# Altium schematic sheet Board03Schematic.SchDoc
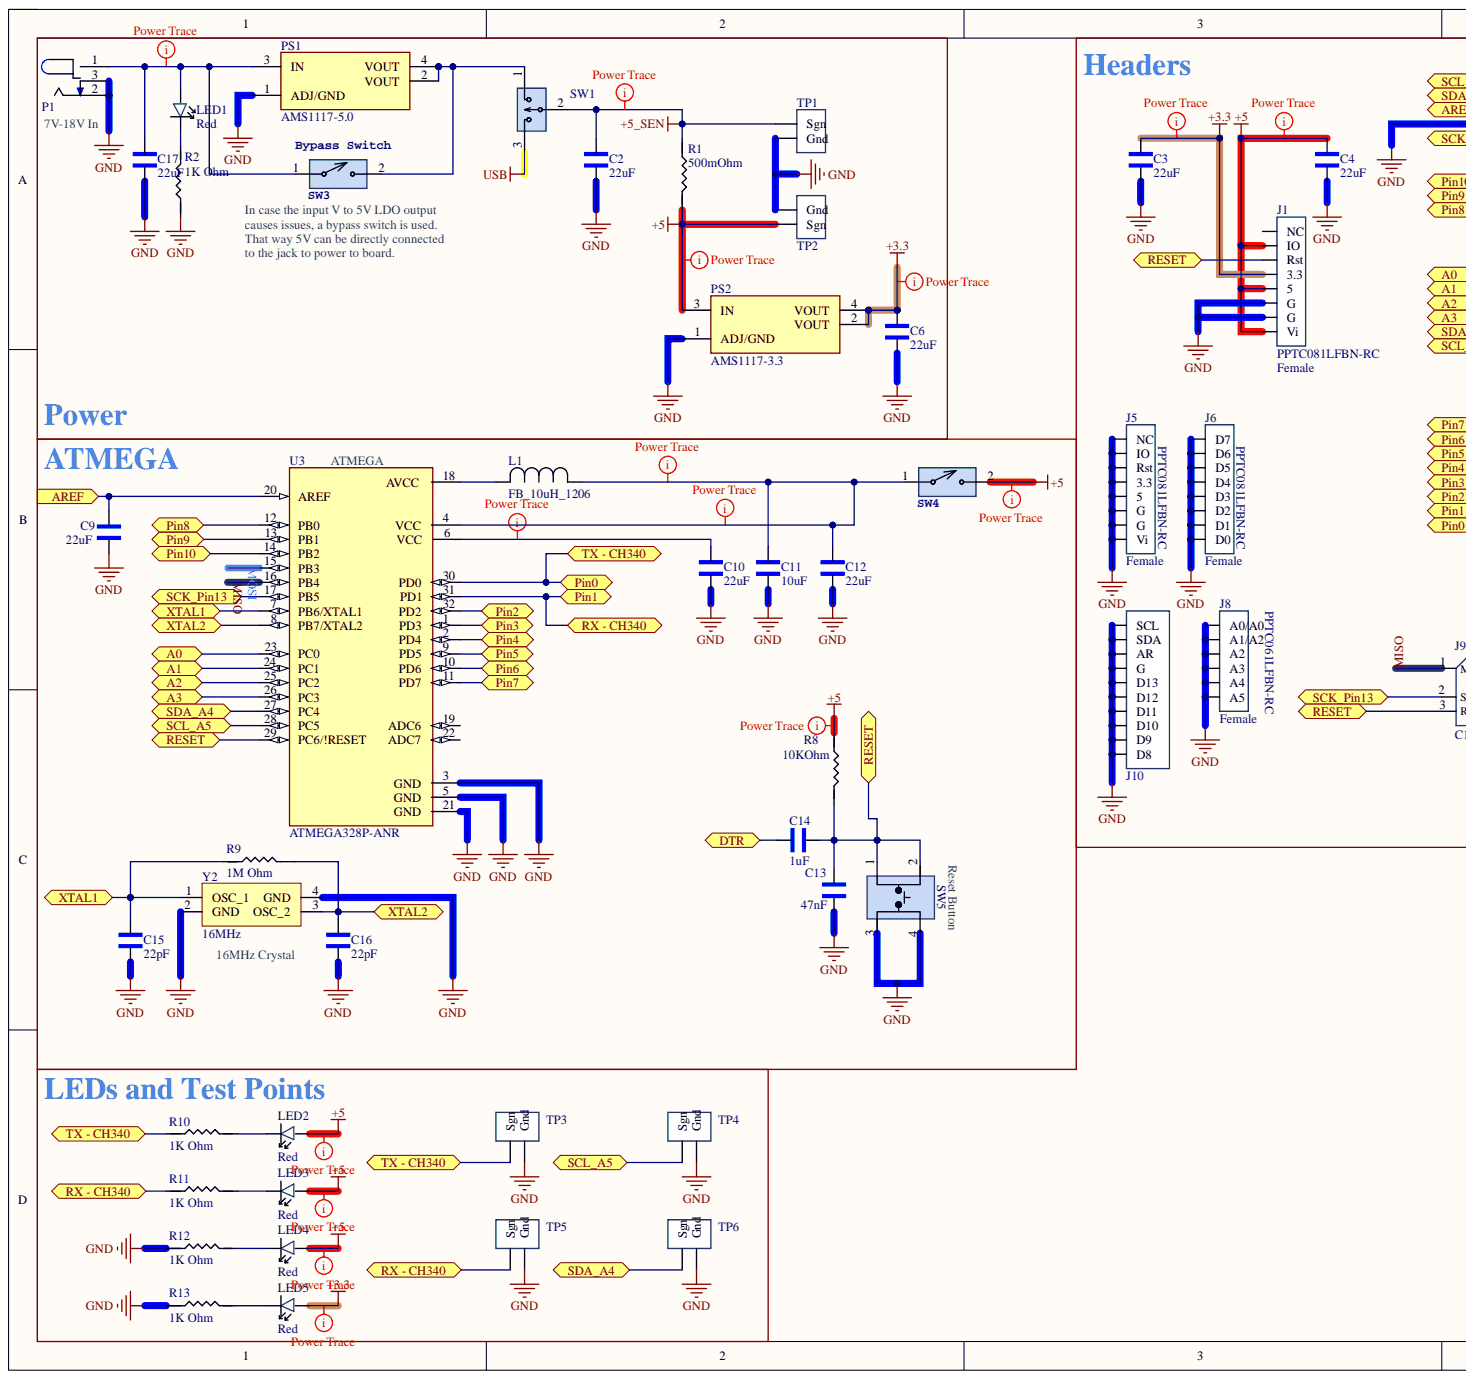
[diagram p0: Board03Schematic.SchDoc, left]
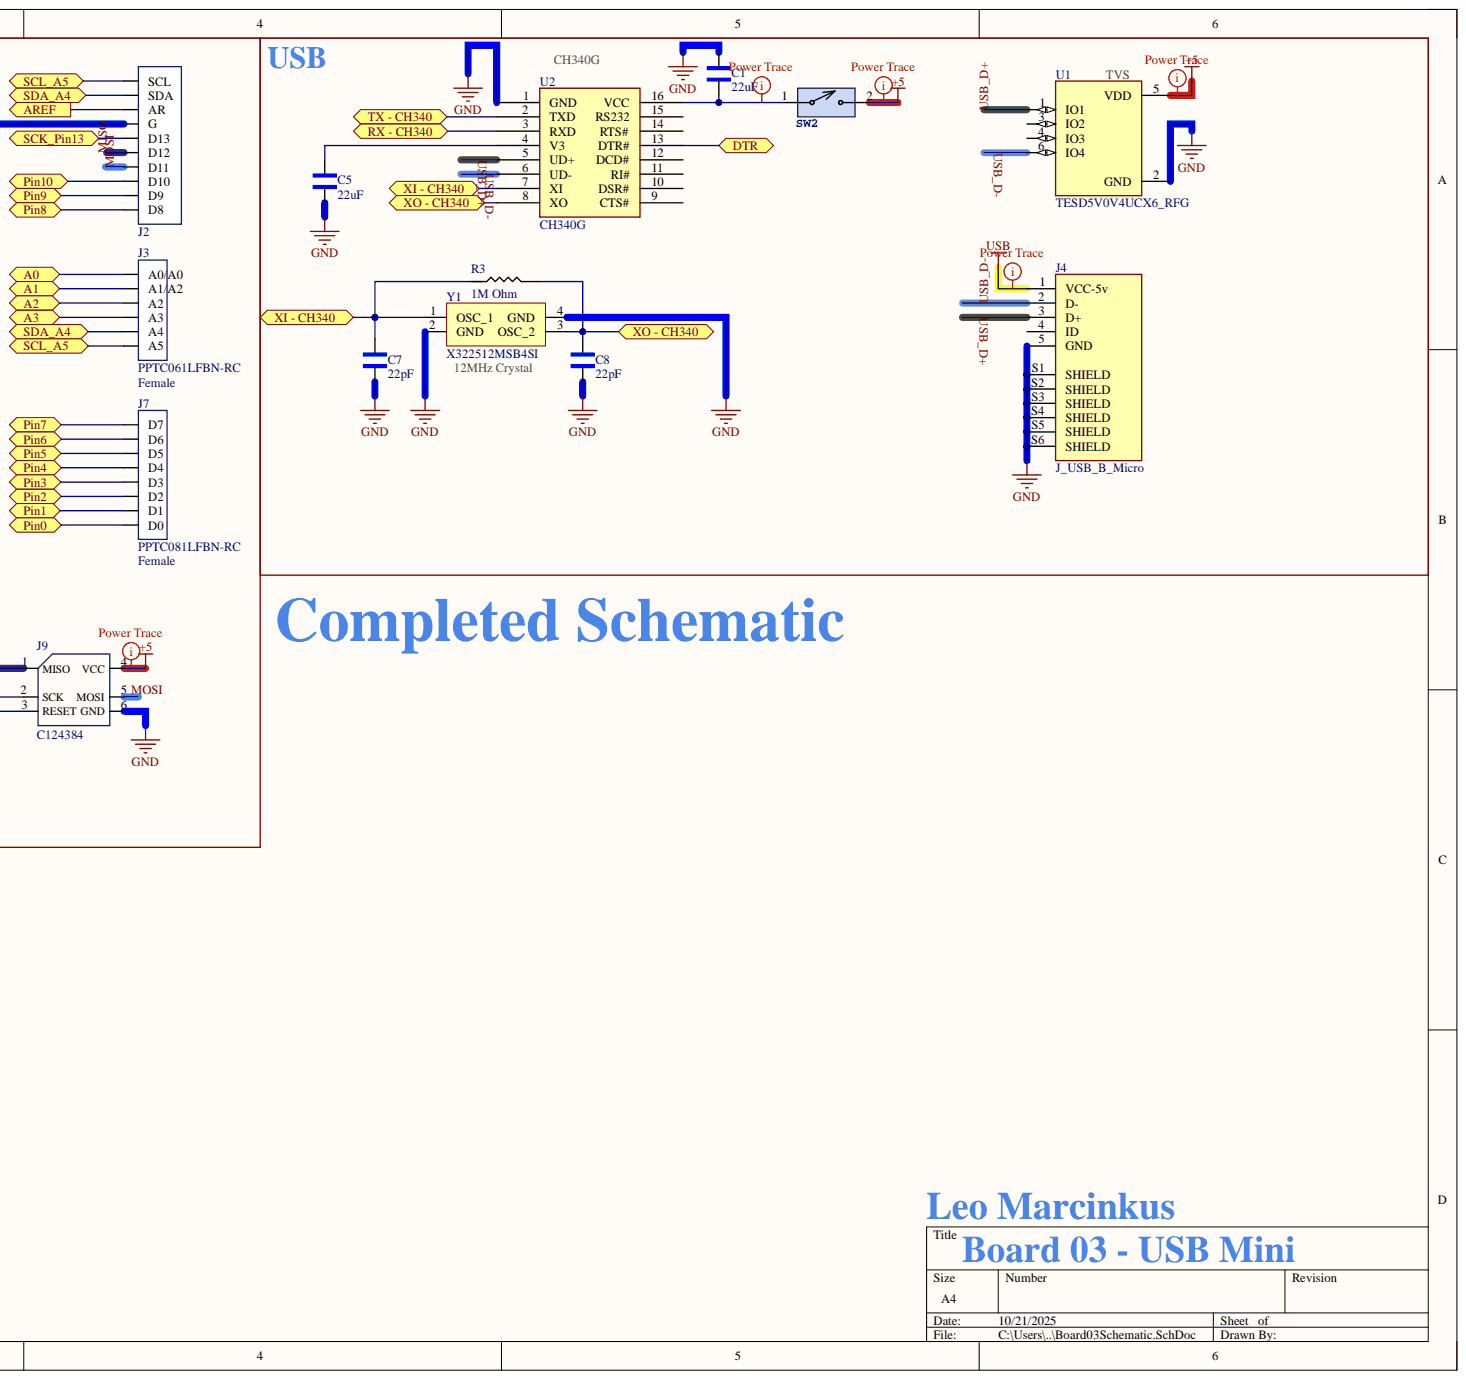
[diagram p1: Board03Schematic.SchDoc, right]

## Parts
C1  22uF  [1206_Passive_Capacitor]
C2  22uF  [1206_Passive_Capacitor]
C3  22uF  [1206_Passive_Capacitor]
C4  22uF  [1206_Passive_Capacitor]
C5  22uF  [1206_Passive_Capacitor]
C6  22uF  [1206_Passive_Capacitor]
C7  22pF  [1206_Passive_Capacitor]
C8  22pF  [1206_Passive_Capacitor]
C9  22uF  [1206_Passive_Capacitor]
C10  22uF  [1206_Passive_Capacitor]
C11  10uF  [1206_Passive_Capacitor]
C12  22uF  [1206_Passive_Capacitor]
C13  47nF  [1206_Passive_Capacitor]
C14  1uF  [1206_Passive_Capacitor]
C15  22pF  [1206_Passive_Capacitor]
C16  22pF  [1206_Passive_Capacitor]
C17  22uF  [1206_Passive_Capacitor]
J1  PPTC081LFBN-RC  value Female  [8_PIN_ARD-PWR_100MIL]
J2  PPTC101LFBN-RC  [10_PIN_ARDUINO_100MIL]  (value not drawn)
J3  PPTC061LFBN-RC  [6_PIN_ARD-Analog_100mil]
J4  J_USB_B_Micro  [AMPHENOL_10118194-0001LF]
J5  PPTC081LFBN-RC  value Female  [8_PIN_ARD-PWR_100MIL]
J6  PPTC081LFBN-RC  value Female  [8_PIN_ARD-DIG_100MIL]
J7  PPTC081LFBN-RC  value Female  [8_PIN_ARD-DIG_100MIL]
J8  PPTC061LFBN-RC  value Female  [6_PIN_ARD-Analog_100mil]
J9  LCSC Part#: C124384  [ICSP]  (hidden comment: NRPN032PAEN-RC)
J10  PPTC101LFBN-RC  [10_PIN_ARDUINO_100MIL]  (value not drawn)
L1  FB_10uH_1206  [AIML-1206_ABR-M]
LED1  Red  [LED_1206]
LED2  Red  [LED_1206]
LED3  Red  [LED_1206]
LED4  Red  [LED_1206]
LED5  Red  [LED_1206]
P1  Power Jack  [Power_Jack]  (value not drawn)
PS1  AMS1117-5.0  [LDO]
PS2  AMS1117-3.3  [LDO]
R1  500m  value 500mOhm  [1206_Passive_Resitor]
R2  value 1K Ohm  [1206_Passive_Resitor]  (hidden comment: 1k)
R3  1M  value 1M Ohm  [1206_Passive_Resitor]
R8  value 10KOhm  [1206_Passive_Resitor]  (hidden comment: 10k)
R9  1M  value 1M Ohm  [1206_Passive_Resitor]
R10  value 1K Ohm  [1206_Passive_Resitor]  (hidden comment: 1k)
R11  value 1K Ohm  [1206_Passive_Resitor]  (hidden comment: 1k)
R12  value 1K Ohm  [1206_Passive_Resitor]  (hidden comment: 1k)
R13  value 1K Ohm  [1206_Passive_Resitor]  (hidden comment: 1k)
SW1  PREC003SAAN-RC  [3_PIN_100mil]  (value not drawn)
SW2  SW_2Pin_100mil_Switch  [2Pin-Header-Conn]  (value not drawn)
SW3  Bypass Switch  [2Pin-Header-Conn]
SW4  SW_2Pin_100mil_Switch  [2Pin-Header-Conn]  (value not drawn)
SW5  TL3305AF160QG  [Switch_JLC]  (value not drawn)
TP1  10x Probe TP  [TP10x_Probe]  (value not drawn)
TP2  10x Probe TP  [TP10x_Probe]  (value not drawn)
TP3  10x Probe TP  [TP10x_Probe]  (value not drawn)
TP4  10x Probe TP  [TP10x_Probe]  (value not drawn)
TP5  10x Probe TP  [TP10x_Probe]  (value not drawn)
TP6  10x Probe TP  [TP10x_Probe]  (value not drawn)
U1  TESD5V0V4UCX6_RFG  [SOT95P280X145-6N]
U2  CH340G  [USB to serial]
U3  ATMEGA328P-ANR  [ATMega328P]
Y1  X322512MSB4SI  value 12MHz  [Crystal resonator]
Y2  value 16MHz  [Crystal resonator]  (hidden comment: X322516MLB4SI)

## Ports
A0, A1, A2, A3, AREF, DTR, Pin0, Pin1, Pin2, Pin3, Pin4, Pin5, Pin6, Pin7, Pin8, Pin9, Pin10, RESET, RX - CH340, SCK_Pin13, SCL_A5, SDA_A4, TX - CH340, XI - CH340, XO - CH340, XTAL1, XTAL2

## Nets
+3.3: C3.2 C6.2 J1.4(3.3) LED5.1 PS2.2(VOUT) PS2.4(VOUT)
+5: C4.2 J1.2(IO) J1.5 J1.8(Vi) J9.4(VCC) LED2.1 LED3.1 LED4.1 PS2.3(IN) R1.2 R8.1 SW2.2 SW4.2 TP2.1 U1.5(VDD)
+5_SEN: C2.2 R1.1 SW1.2 TP1.1
GND: C1.2 C2.1 C3.1 C4.1 C5.1 C6.1 C7.1 C8.1 C9.1 C10.1 C11.1 C12.1 C13.2 C15.1 C16.1 C17.1 J1.6(G) J1.7(G) J2.4(G) J4.5(GND) J4.S1(SHIELD) J4.S2(SHIELD) J4.S3(SHIELD) J4.S4(SHIELD) J4.S5(SHIELD) J4.S6(SHIELD) J5.1(NC) J5.2(IO) J5.3(Rst) J5.4(3.3) J5.5 J5.6(G) J5.7(G) J5.8(Vi) J6.1(D7) J6.2(D6) J6.3(D5) J6.4(D4) J6.5(D3) J6.6(D2) J6.7(D1) J6.8(D0) J8.1 J8.2 J8.3(A2) J8.4(A3) J8.5(A4) J8.6(A5) J9.6(GND) J10.1(SCL) J10.2(SDA) J10.3(AR) J10.4(G) J10.5(D13) J10.6(D12) J10.7(D11) J10.8(D10) J10.9(D9) J10.10(D8) P1.2 P1.3 PS1.1(ADJ/GND) PS2.1(ADJ/GND) R2.1 R12.1 R13.1 SW5.3 SW5.4 TP1.2 TP2.2 TP3.2 TP4.2 TP5.2 TP6.2 U1.2(GND) U2.1(GND) U3.3(GND) U3.5(GND) U3.21(GND) Y1.2(GND) Y1.4(GND) Y2.2(GND) Y2.4(GND)
USB: J4.1(VCC-5v) SW1.3
A0: J3.1 U3.23(PC0) ; port A0
A1: J3.2 U3.24(PC1) ; port A1
A2: J3.3(A2) U3.25(PC2) ; port A2
A3: J3.4(A3) U3.26(PC3) ; port A3
AREF: C9.2 J2.3(AR) U3.20(AREF) ; port AREF
DTR: C14.1 U2.13(DTR#) ; port DTR
MISO: J2.6(D12) J9.1(MISO) U3.16(PB4)
MOSI: J2.7(D11) J9.5(MOSI) U3.15(PB3)
Pin0: J7.8(D0) R10.1 TP3.1 U2.2(TXD) U3.30(PD0) ; port Pin0, port TX - CH340
Pin1: J7.7(D1) R11.1 TP5.1 U2.3(RXD) U3.31(PD1) ; port Pin1, port RX - CH340
Pin2: J7.6(D2) U3.32(PD2) ; port Pin2
Pin3: J7.5(D3) U3.1(PD3) ; port Pin3
Pin4: J7.4(D4) U3.2(PD4) ; port Pin4
Pin5: J7.3(D5) U3.9(PD5) ; port Pin5
Pin6: J7.2(D6) U3.10(PD6) ; port Pin6
Pin7: J7.1(D7) U3.11(PD7) ; port Pin7
Pin8: J2.10(D8) U3.12(PB0) ; port Pin8
Pin9: J2.9(D9) U3.13(PB1) ; port Pin9
Pin10: J2.8(D10) U3.14(PB2) ; port Pin10
RESET: C13.1 C14.2 J1.3(Rst) J9.3(RESET) R8.2 SW5.1 SW5.2 U3.29(PC6/!RESET) ; port RESET
SCK_Pin13: J2.5(D13) J9.2(SCK) U3.17(PB5) ; port SCK_Pin13
SCL_A5: J2.1(SCL) J3.6(A5) TP4.1 U3.28(PC5) ; port SCL_A5
SDA_A4: J2.2(SDA) J3.5(A4) TP6.1 U3.27(PC4) ; port SDA_A4
USB_D+: J4.3(D+) U1.1(IO1) U2.5(UD+)
USB_D-: J4.2(D-) U1.6(IO4) U2.6(UD-)
XI - CH340: C7.2 R3.1 U2.7(XI) Y1.1(OSC_1) ; port XI - CH340
XO - CH340: C8.2 R3.2 U2.8(XO) Y1.3(OSC_2) ; port XO - CH340
XTAL1: C15.2 R9.1 U3.7(PB6/XTAL1) Y2.1(OSC_1) ; port XTAL1
XTAL2: C16.2 R9.2 U3.8(PB7/XTAL2) Y2.3(OSC_2) ; port XTAL2
NetC1_1: C1.1 SW2.1 U2.16(VCC)
NetC5_2: C5.2 U2.4(V3)
NetC10_2: C10.2 U3.6(VCC)
NetC11_2: C11.2 C12.2 L1.1 SW4.1 U3.4(VCC)
NetC17_2: C17.2 LED1.1 P1.1 PS1.3(IN) SW3.1
NetL1_2: L1.2 U3.18(AVCC)
NetLED1_2: LED1.2 R2.2
NetLED2_2: LED2.2 R10.2
NetLED3_2: LED3.2 R11.2
NetLED4_2: LED4.2 R12.2
NetLED5_2: LED5.2 R13.2
NetPS1_2: PS1.2(VOUT) PS1.4(VOUT) SW1.1 SW3.2

Not connected: J1 1(NC); J4 4(ID); U1 3(IO2) 4(IO3); U2 9(CTS#) 10(DSR#) 11(RI#) 12(DCD#) 14(RTS#) 15(RS232); U3 19(ADC6) 22(ADC7)

## Library data of the parts
C1-C6 C9 C10 C12 C17 = C_22uF_1206: 22uF ±10% 25V X5R 1206 Multilayer Ceramic Capacitors MLCC - SMD/SMT RoHS; MFR Part #: CL31A226KAHNNNE; Type: basic; Component Kind: Standard; Datasheet: https://datasheet.lcsc.com/lcsc/1811031514_Samsung-Electro-Mechanics-CL31A226KAHNNNE_C12891.pdf; LCSC Part#: C12891; Value: 22uF; Library Name: ECEN5730LibUpdated.IntLib
C7 C8 C15 C16 = C_22pF_1206: 22pF ±5% 1kV C0G 1206 Multilayer Ceramic Capacitors MLCC - SMD/SMT RoHS; MFR Part #: CC1206JKNPOCBN220; Type: Extended; Component Kind: Standard; Datasheet: https://datasheet.lcsc.com/lcsc/1810241219_YAGEO-CC1206JKNPOCBN220_C107174.pdf; LCSC Part#: C107174; Value: 22pF; Library Name: ECEN5730LibUpdated.IntLib
C11 = C_10uF_1206: 10uF ±10% 50V X5R 1206 Multilayer Ceramic Capacitors MLCC - SMD/SMT RoHS; MFR Part #: CL31A106KBHNNNE; Type: Basic; Component Kind: Standard; Datasheet: https://datasheet.lcsc.com/lcsc/1810221112_Samsung-Electro-Mechanics-CL31A106KBHNNNE_C13585.pdf; LCSC Part#: C13585; Value: 10uF; Library Name: ECEN5730LibUpdated.IntLib
C13 = C_47nF_1206: 47nF ±5% 50V C0G 1206 Multilayer Ceramic Capacitors MLCC - SMD/SMT RoHS; MFR Part #: GRM31M5C1H473JA01L; Type: Extended; Component Kind: Standard; Datasheet: https://datasheet.lcsc.com/lcsc/1810201313_Murata-Electronics-GRM31M5C1H473JA01L_C21812.pdf; LCSC Part#: C21812; Value: 47nF; Library Name: ECEN5730LibUpdated.IntLib
C14 = C_1uF_1206: MULTILAYER CERAMIC CAPACITORS MLCC - SMD/SMT 1UF 50V 1206 ROHS; MFR Part #: CL31B105KBHNNNE; Type: Basic; Component Kind: Standard; Digikey Link: https://www.digikey.com/product-detail/en/C1206C105M4RACTU/399-8150-1-ND/3471873/?itemSeq=304982366; LCSC Part#: C1848; Value: 1uF; Library Name: ECEN5730LibUpdated.IntLib
J1 J5 = JF_8_PIN_FEMA_ARD-Pwr: 8 Position Header Connector 0.100" (2.54mm) Through Hole Tin; Component Kind: Standard; Digikey Link: https://www.digikey.com/product-detail/en/PPTC081LFBN-RC/S7006-ND/810147/?itemSeq=304982354; LCSC Part#: C27438; MFR Part #: PPTC081LFBN-RC; Type: Extended; Library Name: ECEN5730LibUpdated.IntLib
J2 J10 = JF_10_PIN_FEMA_ARDUINO: 10 Position Header Connector 0.100" (2.54mm) Through Hole Tin; Value: Female; Component Kind: Standard; Digikey Link: https://www.digikey.com/product-detail/en/PPTC101LFBN-RC/S7008-ND/810149/?itemSeq=304982352; LCSC Part#: C225507; MFR Part #: PPTC101LFBN-RC; Type: Extended; Library Name: ECEN5730LibUpdated.IntLib
J3 = JF_6_PIN_FEMA_ARD-Anolog: 6 Position Header Connector 0.100" (2.54mm) Through Hole Tin; Value: Female; Component Kind: Standard; Digikey Link: https://www.digikey.com/product-detail/en/PPTC061LFBN-RC/S7004-ND/810145/?itemSeq=304982353; LCSC Part#: C40877; MFR Part #: PPTC061LFBN-RC; Type: Extended; Library Name: ECEN5730LibUpdated.IntLib
J4 = J_USB_B_Micro: Micro Usb, 2.0 Type b, Rcpt, Smt; MFR: Amphenol ICC; MFR Part #: 10118194-0001LF; Component Kind: Standard; DataSheet: https://www.amphenol-cs.com/media/wysiwyg/files/drawing/10118194.pdf; Digikey link: https://www.digikey.com/en/products/detail/amphenol-cs-fci/10118194-0001LF/2785389?s=N4IgTCBcDaIAQEYAMCEA4EE4AsBaJBCAMgGJwgC6AvkA; LCSC Part#: C132563; Type: Extended; Library Name: ECEN5730LibUpdated.IntLib
J6 J7 = JF_8_PIN_FEMA_ARD-Dig: 8 Position Header Connector 0.100" (2.54mm) Through Hole Tin; Component Kind: Standard; Digikey Link: https://www.digikey.com/product-detail/en/PPTC081LFBN-RC/S7006-ND/810147/?itemSeq=304982354; LCSC Part#: C27438; MFR Part #: PPTC081LFBN-RC; Type: Extended; Library Name: ECEN5730LibUpdated.IntLib
J8 = JF_6_PIN_FEMA_ARD-Anolog: 6 Position Header Connector 0.100" (2.54mm) Through Hole Tin; Component Kind: Standard; Digikey Link: https://www.digikey.com/product-detail/en/PPTC061LFBN-RC/S7004-ND/810145/?itemSeq=304982353; LCSC Part#: C40877; MFR Part #: PPTC061LFBN-RC; Type: Extended; Library Name: ECEN5730LibUpdated.IntLib
J9 = J_ICSP: Connector Header Through Hole 6 position 0.079" (2.00mm); Component Kind: Standard; Digikey Link: https://www.digikey.com/product-detail/en/NRPN032PAEN-RC/S5801-03-ND/804955/?itemSeq=304982355; MFR Part #: NRPN032PAEN-RC; Type: Extended; Library Name: ECEN5730LibUpdated.IntLib
L1 = FB_10uH_1206: 25mA 10uH ±10% 800mΩ 1206  Inductors (SMD) ROHS; MFR PART #: CMI321609X100KT; Mfr_Name: FH (Guangdong Fenghua Advanced Tech); Type: Basic; Component Kind: Standard; DataSheet: https://datasheet.lcsc.com/lcsc/1810311314_FH--Guangdong-Fenghua-Advanced-Tech-CMI321609X100KT_C1051.pdf; LCSC PART#: C1051; Library Name: ECEN5730LibUpdated.IntLib
LED1-LED5 = LED_RED_1206: Red 621~631nm 1206 Light Emitting Diodes (LED) RoHS; MFR Part #: 15-21/R6C-FQ1R1B/2T; Type: Extended; Component Kind: Standard; Datasheet: https://datasheet.lcsc.com/szlcsc/1811151241_Everlight-Elec-15-21-R6C-FQ1R1B-2T_C93133.pdf; LCSC Part#: C93133; Value: Red; Library Name: ECEN5730LibUpdated.IntLib
P1 = P_Power Jack: Power Barrel Connector Jack 2.10mm ID (0.083"), 5.50mm OD (0.217") Through Hole, Right Angle; MFR Part #: PJ-102A; Value: CONN PWR JACK 2X5.5MM SOLDER; Component Kind: Standard; Digikey Link: https://www.digikey.com/product-detail/en/PJ-102A/CP-102A-ND/275425/?itemSeq=304982367; LCSC Part#: C136744; Type: Extended; Library Name: ECEN5730LibUpdated.IntLib
PS1 = PS_AMS_LDO_5V: LOW DROPOUT REGULATORS(LDO) POSITIVE FIXED 1.3V @ 1A 15V 5V 1A SOT-223 ROHS; Value: IC REG LINEAR 5V 1A SOT223; Component Kind: Standard; LCSC Part#: C6187; MFR Part #: AMS1117-5.0; Type: Basic; Library Name: ECEN5730LibUpdated.IntLib
PS2 = PS_AMS_LDO_3v3: LOW DROPOUT REGULATORS(LDO) POSITIVE FIXED 1.3V @ 800MA 15V 3.3V 1A SOT-223 ROHS; Value: IC REG LINEAR 3.3V 800MA SOT223; Component Kind: Standard; LCSC Part#: C6186; MFR Part #: AMS1117-3.3; Type: Basic; Library Name: ECEN5730LibUpdated.IntLib
R1 = R_500mOhm_1206: Resistors/Shunt 250mW 500mΩ ±800ppm/℃ ±5% 1206  Current Sense  Resistors ROHS; MFR Part #: 1206W4J050KT5E; Component Kind: Standard; Datasheet: https://datasheet.lcsc.com/lcsc/1810301438_UNI-ROYAL-Uniroyal-Elec-1206W4J050KT5E_C247433.pdf; LCSC Part#: C247433; Type: Extended; Library Name: ECEN5730LibUpdated.IntLib
R2 R10-R13 = R_1K_1206: CHIP RESISTOR - SURFACE MOUNT 1KOHMS ±1% 1/4W 1206 ROHS; MFR Part #: RNCP1206FTD1K00CT; Component Kind: Standard; Digikey Link: https://www.digikey.com/product-detail/en/RNCP1206FTD1K00/RNCP1206FTD1K00CT-ND/2240675/?itemSeq=304982373; LCSC Part#: C4410; Type: Basic; Library Name: ECEN5730LibUpdated.IntLib
R3 R9 = R_1M_1206: CHIP RESISTOR - SURFACE MOUNT 1MOHMS ±1% 1/4W 1206 ROHS; MFR Part #: RMCF1206JT1M00; Component Kind: Standard; Digikey Link: https://www.digikey.com/product-detail/en/RMCF1206JT1M00/RMCF1206JT1M00CT-ND/1942830/?itemSeq=304982358; LCSC Part#: C17927; Type: Basic; Library Name: ECEN5730LibUpdated.IntLib
R8 = R_10KOhm_1206: 10 kOhms ±5% 0.25W, 1/4W Chip Resistor 1206 (3216 Metric) Automotive AEC-Q200 Thick Film; MFR Part #: RMCF1206FT10K0; Component Kind: Standard; Digikey Link: https://www.digikey.com/en/products/detail/stackpole-electronics-inc/RMCF1206FT10K0/1759669; LCSC Part#: C17902; Type: Basic; Library Name: ECEN5730LibUpdated.IntLib
SW1 = SW_3Pin_100mil_Switch: Connector Header Through Hole 3 position 0.100" (2.54mm); Value: CONN HEADER VERT 3POS 2.54MM; Component Kind: Standard; Digikey Link: https://www.digikey.com/product-detail/en/PREC003SAAN-RC/S1012EC-03-ND/2774851/?itemSeq=304982371; LCSC Part#: C49257; MFR Part #: PREC003SAAN-RC; Type: Extended; Library Name: ECEN5730LibUpdated.IntLib
SW2-SW4 = SW_2Pin_100mil_Switch: 2Pin Header; Component Kind: Standard; LCSC Part#: C124375; Type: Extended; Library Name: ECEN5730LibUpdated.IntLib
SW5 = SW_Push_Button_JLC: Tactile Switch SPST-NO Top Actuated Surface Mount; MFR Part #: KH-4.5X4.5X4.3H-STM; Component Kind: Standard; DataSheet: https://datasheet.lcsc.com/lcsc/2106081532_Shenzhen-Kinghelm-Elec-KH-4.5X4.5X4.3H-STM_C2837550.pdf; LCSC Part#: C2837550; Type: Extended; Library Name: ECEN5730LibUpdated.IntLib
TP1-TP6 = TP_10x_Probe: Test Point 300 mil centers; Component Kind: Standard; Library Name: ECEN5730LibUpdated.IntLib
U1 = TESD5V0V4UCX6_RFG: SOT-26, 5V, 75W, 0.6pF, ESD Protection; MF: Taiwan Semiconductor; MP: TESD5V0V4UCX6 RFG; Check_prices: https://www.snapeda.com/parts/TESD5V0V4UCX6%20RFG/Taiwan+Semiconductor/view-part/?ref=eda; Component Kind: Standard; Package: None; SnapEDA_Link: https://www.snapeda.com/parts/TESD5V0V4UCX6%20RFG/Taiwan+Semiconductor/view-part/?ref=snap; Library Name: ECEN5730LibUpdated.IntLib
U2 = U_USBtoSerial: Transceiver USB 2.0 2Mbps SOP-16_150mil USB ICs RoHS; Component Kind: Standard; Datasheet: https://datasheet.lcsc.com/lcsc/2008191806_WCH-Jiangsu-Qin-Heng-CH340G_C14267.pdf; LCSC Part#: C14267; MFR Part #: CH340G; Type: Basic; Value: USB to Serial; Library Name: ECEN5730LibUpdated.IntLib
U3 = U_ATMega328P: AVR AVR® ATmega Microcontroller IC 8-Bit 20MHz 32KB (16K x 16) FLASH 32-TQFP (7x7); MFR Part #: ATMEGA328P-AU; Value: IC MCU 8BIT 32KB FLASH 32TQFP; Component Kind: Standard; Digikey Link: https://www.digikey.com/product-detail/en/ATMEGA328P-ANR/ATMEGA328P-ANRCT-ND/2774230/?itemSeq=304982365; LCSC Part#: C14877; Type: Basic; Library Name: ECEN5730LibUpdated.IntLib
Y1 = X_12MHz_Res: SMD Crystal Resonators 12MHz ±10ppm SMD-3225_4P RoHS; Component Kind: Standard; Datasheet: https://datasheet.lcsc.com/szlcsc/Yangxing-Tech-X322512MSB4SI_C9002.pdf; LCSC Part#: C9002; MFR Part #: X322512MSB4SI; Type: Basic; Library Name: ECEN5730LibUpdated.IntLib
Y2 = X_16Mhz_Res: ±10ppm 16000000Hz -40℃~85℃ 贴片无源晶振 60Ω 9pF SMD3225-4P Crystals ROHS; Component Kind: Standard; LCSC Part#: C13738; MFR Part #: X322516MLB4SI; Type: Basic; Library Name: ECEN5730LibUpdated.IntLib
